# Altium schematic sheet hv_io_precharge.SchDoc
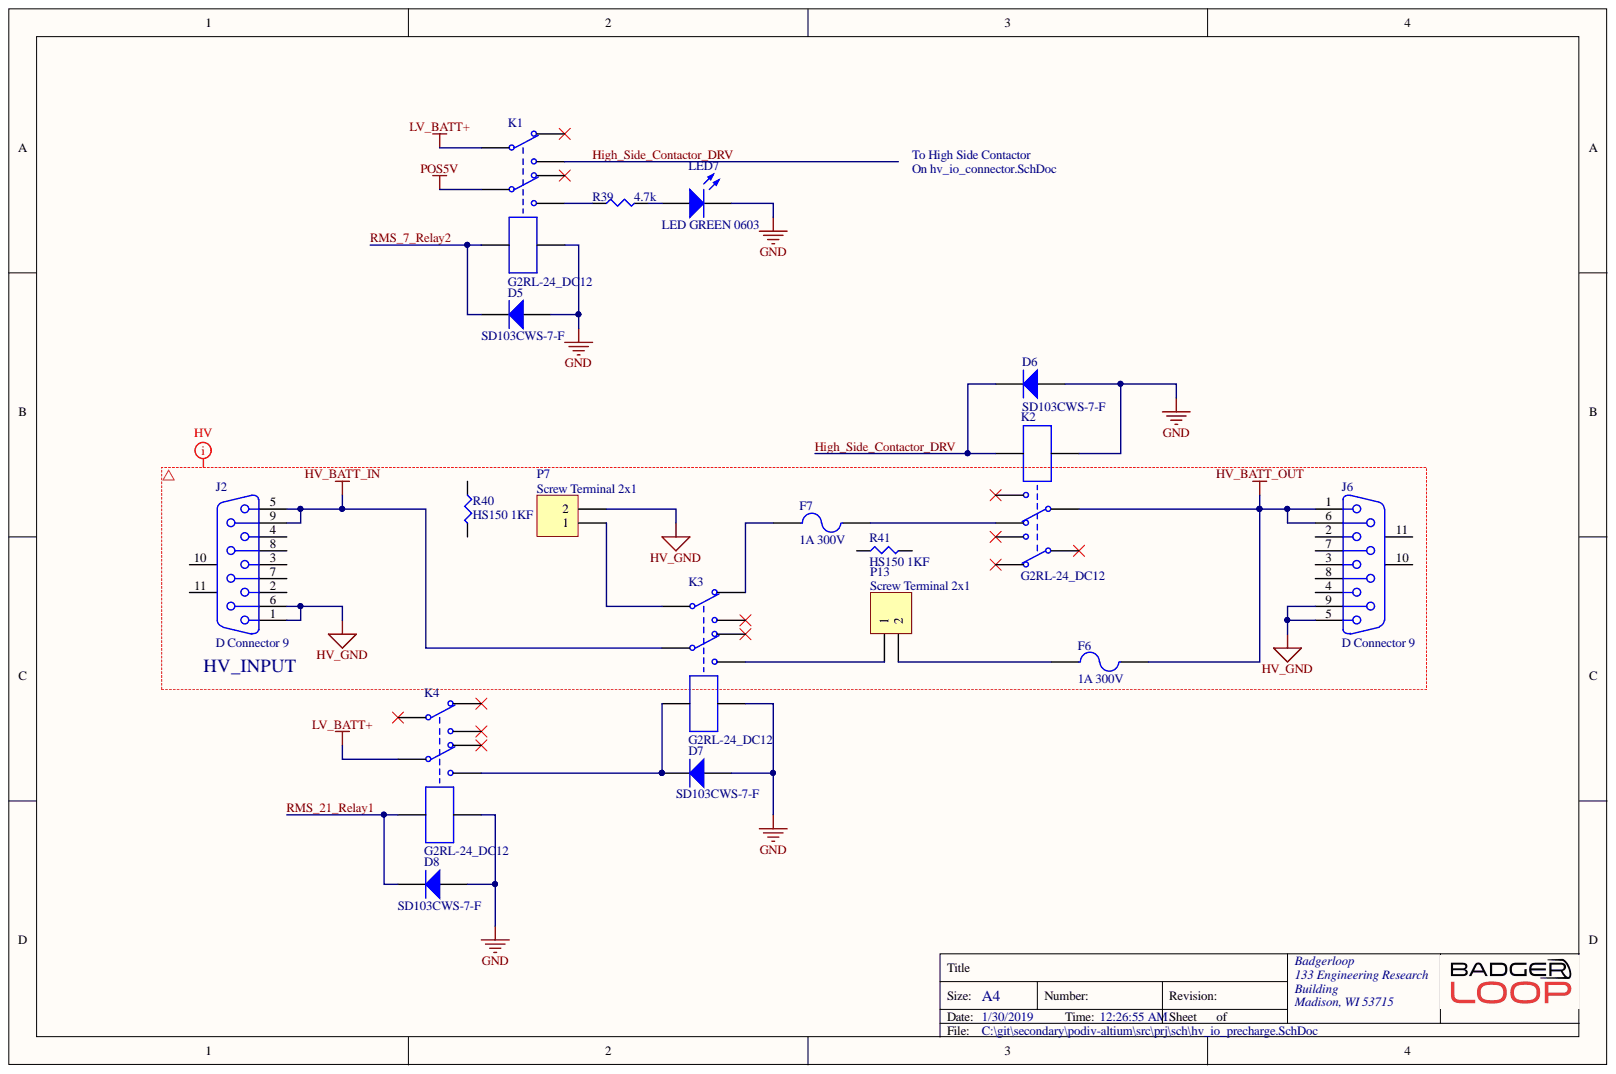
[diagram p0: hv_io_precharge.SchDoc]

## Parts
D5  SD103CWS-7-F  [SODFL250X110-2N]
D6  SD103CWS-7-F  [SODFL250X110-2N]
D7  SD103CWS-7-F  [SODFL250X110-2N]
D8  SD103CWS-7-F  [SODFL250X110-2N]
F6  1A 300V  [SMD-1206-RES]
F7  1A 300V  [SMD-1206-RES]
J2  D Connector 9  [DSUB1.385-2H9]
J6  D Connector 9  [DSUB1.385-2H9]
K1  G2RL-24_DC12  [G2RL]
K2  G2RL-24_DC12  [G2RL]
K3  G2RL-24_DC12  [G2RL]
K4  G2RL-24_DC12  [G2RL]
LED7  LED GREEN 0603  Color: GREEN  Package / Case: 0603  [LED 0603 GREEN]
P7  Screw Terminal 2x1  [2POS TERM BLOCK]
P13  Screw Terminal 2x1  [2POS TERM BLOCK]
R39  4.7k  [SMD-0402-RES]
R40  HS150 1KF  [HS150 1KF]
R41  HS150 1KF  [HS150 1KF]

## Nets
GND: D5.1 D6.1 D7.1 D8.1 K1.8 K2.1 K3.8 K4.8 LED7.2
HV_BATT_IN: J2.5 J2.9 K3.6
HV_BATT_OUT: F6.2 J6.1 J6.6 K2.6
HV_GND: J2.1 J2.6 J6.5 J6.9 P7.2
LV_BATT+: K1.3 K4.6
POS5V: K1.6
High_Side_Contactor_DRV: D6.2 K1.4 K2.8
RMS_7_Relay2: D5.2 K1.1
RMS_21_Relay1: D8.2 K4.1
NetD7_2: D7.2 K3.1 K4.7
NetF6_1: F6.1 P13.2
NetF7_1: F7.1 K3.2
NetF7_2: F7.2 K2.5
NetK1_7: K1.7 R39.1
NetK3_3: K3.3 P7.1
NetK3_7: K3.7 P13.1
NetLED7_1: LED7.1 R39.2
N00213:

Not connected: J2 2 3 4 7 8 10 11; J6 2 3 4 7 8 10 11; K1 2 5; K2 2 3 4 7; K3 4 5; K4 2 3 4 5; R40 1 2; R41 1 2

## Library data of the parts
D5-D8 = Diode General Purpose_2: DIODE GEN PURP 20V 350MA SOD323; Manufacturer: Diodes Incorporated; Component Kind: Standard; ComponentLink1Description: Digikey; ComponentLink1URL: https://www.digikey.com/product-detail/en/diodes-incorporated/SD103CWS-7-F/SD103CWS-FDIDKR-ND/1836772; ComponentLink2Description: Datasheet; ComponentLink2URL: https://www.diodes.com/assets/Datasheets/ds30101.pdf; ComponentLink3Description: Dimensions; ComponentLink3URL: https://www.diodes.com/assets/Package-Files/SOD323.pdf; Digikey P/N: SD103CWS-FDIDKR-ND; Library Name: misc.SchLib; Manufacturer P/N: SD103CWS-7-F; Package / Case: SOD323
J2 J6 = D Connector 9: Receptacle Assembly, 9 Position, Right Angle; PackageDocument: O; Positions: 9; Component Kind: Standard; DatasheetDocument: 1-Sep-1999; Gender: Receptacle; LatestRevisionDate: 17-Jul-2002; LatestRevisionNote: Re-released for DXP Platform.; Orientation: Right Angle; PackageReference: 788750; Published: 15-Jun-2001; Signal Integrity: Connector; Publisher: Altium Limited
K1-K4 = G2RL-24_DC12: RELAY GEN PURPOSE DPDT 8A 12V; Manufacturer: Omron Electronics; Manufacturer P/N: G2RL-24 DC12; Component Kind: Standard; Digikey Link: https://www.digikey.com/product-detail/en/omron-electronics-inc-emc-div/G2RL-24-DC12/Z147-ND/307667; Digikey P/N: Z147-ND
LED7 = LED GREEN 0603: Manufacturer: Lite-On Inc.; Manufacturer P/N: LTST-C190KGKT; Component Kind: Standard; Digikey P/N: 160-1435-1-ND; Library Name: misc.SchLib
P7 P13 = Screw Terminal 2x1: Screw Terminal, 2-Pin; Component Kind: Standard; Library Name: connector.SchLib; Signal Integrity: Connector
R39 = ERJ-2GEJ472X: RES SMD 4.7K OHM 5% 1/10W 0402; Manufacturer: Panasonic Electronic Components; @ qty: 1500; Factory Stock: 0; Minimum Quantity: 1; Power (Watts): 0.1W, 1/10W; Temperature Coefficient: ±200ppm/°C; Unit Price (USD): 0.00350; Component Kind: Standard; Composition: Thick Film; Datasheets: http://industrial.panasonic.com/www-cgi/jvcr13pz.cgi?E+PZ+3+AOA0001+ERJ2GEJ472X+7+WW; Digi-Key Part Number: P4.7KJCT-ND; Digikey P/N: ERJ-2GEJ472X; Failure Rate: -; Features: Automotive AEC-Q200; Height - Seated (Max): 0.016" (0.40mm); Image: //media.digikey.com/Photos/Panasonic%20Photos/ERJ-PA2-Series.jpg; Library Name: resistor.SchLib; Number of Terminations: 2; Operating Temperature: -55°C ~ 155°C; Package / Case: 0402 (1005 Metric); Packaging: Cut Tape (CT); Part Number: ERJ-2GEJ472X; Part Status: Active; Quantity Available: 8551774; Series: ERJ; Size / Dimension: 0.039" L x 0.020" W (1.00mm x 0.50mm); Supplier Device Package: 0402; Tolerance: ±5%; Value: 4.7k
R40 R41 = HS150 1KF: 1K Power Resistor; Manufacturer: Ohmite; Component Kind: Standard; Digikey Link: https://www.digikey.com/product-detail/en/ohmite/HS150-1KF/HS1501KF-ND/5306251; Digikey P/N: HS1501KF-ND; Library Name: temp.SchLib; Manufacturer P/N: HS150 1KF
F6 F7 = 1A 300V: Component Kind: Standard; ComponentLink1Description: Manufacturer Data Sheet; ComponentLink1URL: http://www.littelfuse.com/~/media/electronics/datasheets/fuses/littelfuse_fuse_462_datasheet.pdf.pdf; ComponentLink2Description: Digikey; ComponentLink2URL: https://www.digikey.com/product-detail/en/littelfuse-inc/46211000000/F6007CT-ND/4146345; Library Name: temp.SchLib
